# Altium schematic sheet lv_io_analog_input.SchDoc
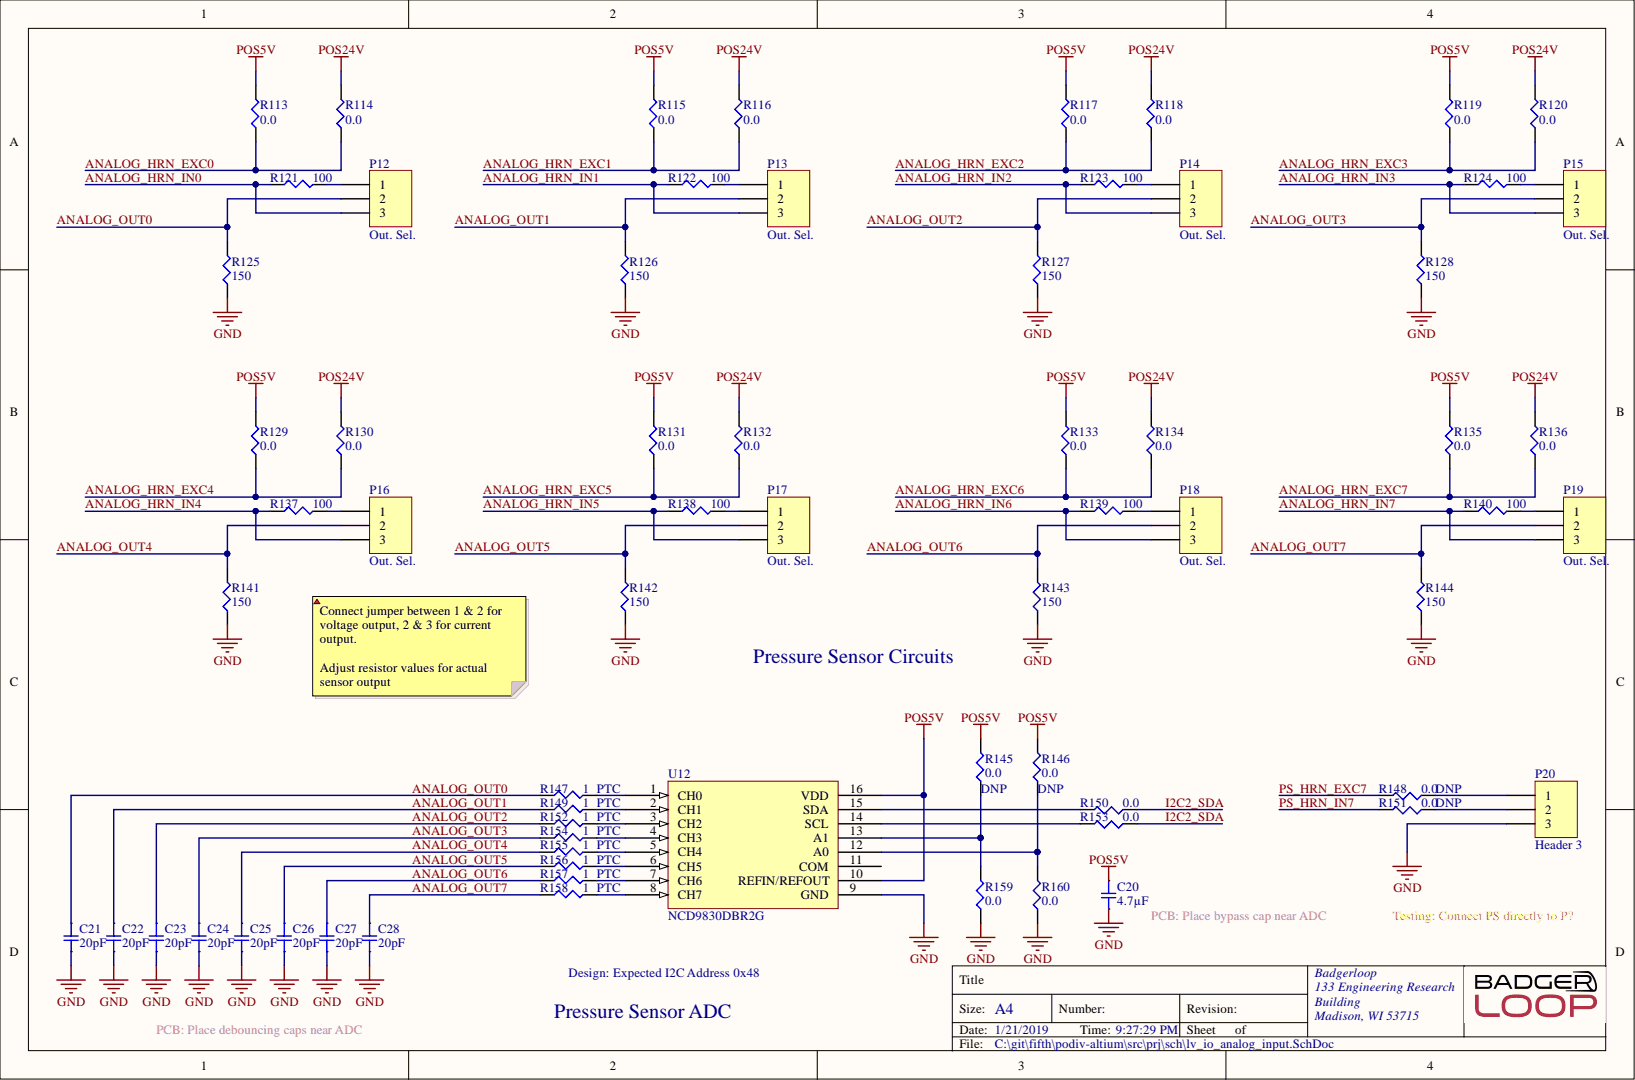
[diagram p0: lv_io_analog_input.SchDoc]

## Parts
C20  4.7µF  [SMD-0805C]
C21  20pF  [SMD-0402C]
C22  20pF  [SMD-0402C]
C23  20pF  [SMD-0402C]
C24  20pF  [SMD-0402C]
C25  20pF  [SMD-0402C]
C26  20pF  [SMD-0402C]
C27  20pF  [SMD-0402C]
C28  20pF  [SMD-0402C]
P12  Out. Sel.  [Pin Header 1x3 TH Pitch 2.54mm]
P13  Out. Sel.  [Pin Header 1x3 TH Pitch 2.54mm]
P14  Out. Sel.  [Pin Header 1x3 TH Pitch 2.54mm]
P15  Out. Sel.  [Pin Header 1x3 TH Pitch 2.54mm]
P16  Out. Sel.  [Pin Header 1x3 TH Pitch 2.54mm]
P17  Out. Sel.  [Pin Header 1x3 TH Pitch 2.54mm]
P18  Out. Sel.  [Pin Header 1x3 TH Pitch 2.54mm]
P19  Out. Sel.  [Pin Header 1x3 TH Pitch 2.54mm]
P20  Header 3  [Pin Header 1x3 TH Pitch 2.54mm]
R113  0.0  [SMD-0402-RES]
R114  0.0  [SMD-0402-RES]
R115  0.0  [SMD-0402-RES]
R116  0.0  [SMD-0402-RES]
R117  0.0  [SMD-0402-RES]
R118  0.0  [SMD-0402-RES]
R119  0.0  [SMD-0402-RES]
R120  0.0  [SMD-0402-RES]
R121  100  [SMD-0402-RES]
R122  100  [SMD-0402-RES]
R123  100  [SMD-0402-RES]
R124  100  [SMD-0402-RES]
R125  150  [SMD-0603-RES]
R126  150  [SMD-0603-RES]
R127  150  [SMD-0603-RES]
R128  150  [SMD-0603-RES]
R129  0.0  [SMD-0402-RES]
R130  0.0  [SMD-0402-RES]
R131  0.0  [SMD-0402-RES]
R132  0.0  [SMD-0402-RES]
R133  0.0  [SMD-0402-RES]
R134  0.0  [SMD-0402-RES]
R135  0.0  [SMD-0402-RES]
R136  0.0  [SMD-0402-RES]
R137  100  [SMD-0402-RES]
R138  100  [SMD-0402-RES]
R139  100  [SMD-0402-RES]
R140  100  [SMD-0402-RES]
R141  150  [SMD-0603-RES]
R142  150  [SMD-0603-RES]
R143  150  [SMD-0603-RES]
R144  150  [SMD-0603-RES]
R145  0.0  [SMD-0402-RES]
R146  0.0  [SMD-0402-RES]
R147  1  Features: PTC  [SMD-0603-RES]
R148  0.0  [SMD-0402-RES]
R149  1  Features: PTC  [SMD-0603-RES]
R150  0.0  [SMD-0402-RES]
R151  0.0  [SMD-0402-RES]
R152  1  Features: PTC  [SMD-0603-RES]
R153  0.0  [SMD-0402-RES]
R154  1  Features: PTC  [SMD-0603-RES]
R155  1  Features: PTC  [SMD-0603-RES]
R156  1  Features: PTC  [SMD-0603-RES]
R157  1  Features: PTC  [SMD-0603-RES]
R158  1  Features: PTC  [SMD-0603-RES]
R159  0.0  [SMD-0402-RES]
R160  0.0  [SMD-0402-RES]
U12  NCD9830DBR2G  [TSOP65P640X120_HS-17N]

## Nets
GND: C20.2 C21.2 C22.2 C23.2 C24.2 C25.2 C26.2 C27.2 C28.2 P20.3 R125.1 R126.1 R127.1 R128.1 R141.1 R142.1 R143.1 R144.1 R159.1 R160.1 U12.9(GND)
POS5V: C20.1 R113.2 R115.2 R117.2 R119.2 R129.2 R131.2 R133.2 R135.2 R145.2 R146.2 U12.10(REFIN/REFOUT) U12.16(VDD)
POS24V: R114.2 R116.2 R118.2 R120.2 R130.2 R132.2 R134.2 R136.2
ANALOG_HRN_EXC0: R113.1 R114.1
ANALOG_HRN_EXC1: R115.1 R116.1
ANALOG_HRN_EXC2: R117.1 R118.1
ANALOG_HRN_EXC3: R119.1 R120.1
ANALOG_HRN_EXC4: R129.1 R130.1
ANALOG_HRN_EXC5: R131.1 R132.1
ANALOG_HRN_EXC6: R133.1 R134.1
ANALOG_HRN_EXC7: R135.1 R136.1
ANALOG_HRN_IN0: P12.3 R121.1
ANALOG_HRN_IN1: P13.3 R122.1
ANALOG_HRN_IN2: P14.3 R123.1
ANALOG_HRN_IN3: P15.3 R124.1
ANALOG_HRN_IN4: P16.3 R137.1
ANALOG_HRN_IN5: P17.3 R138.1
ANALOG_HRN_IN6: P18.3 R139.1
ANALOG_HRN_IN7: P19.3 R140.1
ANALOG_OUT0: C21.1 P12.2 R125.2 R147.1
ANALOG_OUT1: C22.1 P13.2 R126.2 R149.1
ANALOG_OUT2: C23.1 P14.2 R127.2 R152.1
ANALOG_OUT3: C24.1 P15.2 R128.2 R154.1
ANALOG_OUT4: C25.1 P16.2 R141.2 R155.1
ANALOG_OUT5: C26.1 P17.2 R142.2 R156.1
ANALOG_OUT6: C27.1 P18.2 R143.2 R157.1
ANALOG_OUT7: C28.1 P19.2 R144.2 R158.1
I2C2_SDA: R150.1 R153.1
PS_HRN_EXC7: R148.2
PS_HRN_IN7: R151.2
NetP12_1: P12.1 R121.2
NetP13_1: P13.1 R122.2
NetP14_1: P14.1 R123.2
NetP15_1: P15.1 R124.2
NetP16_1: P16.1 R137.2
NetP17_1: P17.1 R138.2
NetP18_1: P18.1 R139.2
NetP19_1: P19.1 R140.2
NetP20_1: P20.1 R148.1
NetP20_2: P20.2 R151.1
NetR145_1: R145.1 R159.2 U12.13(A1)
NetR146_1: R146.1 R160.2 U12.12(A0)
NetR147_2: R147.2 U12.1(CH0)
NetR149_2: R149.2 U12.2(CH1)
NetR150_2: R150.2 U12.15(SDA)
NetR152_2: R152.2 U12.3(CH2)
NetR153_2: R153.2 U12.14(SCL)
NetR154_2: R154.2 U12.4(CH3)
NetR155_2: R155.2 U12.5(CH4)
NetR156_2: R156.2 U12.6(CH5)
NetR157_2: R157.2 U12.7(CH6)
NetR158_2: R158.2 U12.8(CH7)

Not connected: U12 11(COM)

## Library data of the parts
C20 = GRM21BR60J475KA11L: CAP CER 4.7UF 6.3V X5R 0805; Manufacturer: Murata Electronics North America; @ qty: 0; Minimum Quantity: 1; Tolerance: ±10%; Unit Price (USD): 0.28; Applications: General Purpose; Component Kind: Standard; Datasheets: http://www.murata.com/~/media/webrenewal/support/library/catalog/products/capacitor/mlcc/c02e.pdf; Digi-Key Part Number: 490-9749-1-ND; Factory Stock: 0; Features: -; Height - Seated (Max): -; Image: //media.digikey.com/Renders/Murata%20Renders/0805%20(2012%20Metric)%20.85mm.jpg; Lead Spacing: -; Lead Style: -; Library Name: capacitor.SchLib; Mounting Type: Surface Mount, MLCC; Operating Temperature: -55°C ~ 85°C; Package / Case: 0805 (2012 Metric); Packaging: Cut Tape (CT); Part Number: GRM21BR60J475KA11L; Part Status: Active; Quantity Available: 2821; Ratings: -; Series: GRM; Size / Dimension: 0.079" L x 0.049" W (2.00mm x 1.25mm); Temperature Coefficient: X5R; Thickness (Max): 0.055" (1.40mm); Value: 4.7µF; Voltage: 6.3V
C21-C28 = GJM1555C1H200JB01D: CAP CER 20PF 50V NP0 0402; Manufacturer: Murata Electronics North America; @ qty: 0; Minimum Quantity: 1; Temperature Coefficient: C0G, NP0; Tolerance: ±5%; Unit Price (USD): 0.1; Applications: RF, Microwave, High Frequency; Component Kind: Standard; Datasheets: http://www.murata.com/~/media/webrenewal/support/library/catalog/products/capacitor/mlcc/c02e.pdf; Digi-Key Part Number: 490-3120-1-ND; Factory Stock: 0; Features: High Q, Low Loss; Height - Seated (Max): -; Image: //media.digikey.com/Renders/Murata%20Renders/ZRB-SERIES-0402.jpg; Lead Spacing: -; Lead Style: -; Library Name: capacitor.SchLib; Mounting Type: Surface Mount, MLCC; Operating Temperature: -55°C ~ 125°C; Package / Case: 0402 (1005 Metric); Packaging: Cut Tape (CT); Part Number: GJM1555C1H200JB01D; Part Status: Active; Quantity Available: 13133; Ratings: -; Series: GJM; Size / Dimension: 0.039" L x 0.020" W (1.00mm x 0.50mm); Thickness (Max): 0.022" (0.55mm); Value: 20pF; Voltage: 50V
P12-P20 = Header 3: Header, 3-Pin; Component Kind: Standard; LatestRevisionDate: 17-Jul-2002; LatestRevisionNote: Re-released for DXP Platform.; Library Name: connector.SchLib; Signal Integrity: Connector; Publisher: Altium Limited
R113-R120 R129-R136 R145 R146 R148 R150 R151 R153 R159 R160 = ERJ-2GE0R00X: RES SMD 0.0OHM JUMPER 1/10W 0402; Manufacturer: Panasonic Electronic Components; @ qty: 1500; Factory Stock: 0; Minimum Quantity: 1; Power (Watts): 0.1W, 1/10W; Unit Price (USD): 0.00384; Component Kind: Standard; Composition: Thick Film; Datasheets: http://industrial.panasonic.com/www-cgi/jvcr13pz.cgi?E+PZ+3+AOA0001+ERJ2GE0R00X+7+WW; Digi-Key Part Number: P0.0JCT-ND; Failure Rate: -; Features: Automotive AEC-Q200; Height - Seated (Max): 0.016" (0.40mm); Image: //media.digikey.com/Photos/Panasonic%20Photos/ERJ-PA2-Series.jpg; Library Name: resistor.SchLib; Number of Terminations: 2; Operating Temperature: -55°C ~ 155°C; Package / Case: 0402 (1005 Metric); Packaging: Cut Tape (CT); Part Number: ERJ-2GE0R00X; Part Status: Active; Quantity Available: 3152483; Series: ERJ; Size / Dimension: 0.039" L x 0.020" W (1.00mm x 0.50mm); Supplier Device Package: 0402; Temperature Coefficient: -; Tolerance: Jumper; Value: 0.0
  R145 R146 R148 R151: DNP: DNP
R121-R124 R137-R140 = ERJ-2GEJ101X: RES SMD 100 OHM 5% 1/10W 0402; Manufacturer: Panasonic Electronic Components; @ qty: 1500; Factory Stock: 0; Minimum Quantity: 1; Power (Watts): 0.1W, 1/10W; Temperature Coefficient: ±200ppm/°C; Unit Price (USD): 0.00350; Component Kind: Standard; Composition: Thick Film; Datasheets: http://industrial.panasonic.com/www-cgi/jvcr13pz.cgi?E+PZ+3+AOA0001+ERJ2GEJ101X+7+WW; Digi-Key Part Number: P100JCT-ND; Failure Rate: -; Features: Automotive AEC-Q200; Height - Seated (Max): 0.016" (0.40mm); Image: //media.digikey.com/Photos/Panasonic%20Photos/ERJ-PA2-Series.jpg; Library Name: resistor.SchLib; Number of Terminations: 2; Operating Temperature: -55°C ~ 155°C; Package / Case: 0402 (1005 Metric); Packaging: Cut Tape (CT); Part Number: ERJ-2GEJ101X; Part Status: Active; Quantity Available: 3114113; Series: ERJ; Size / Dimension: 0.039" L x 0.020" W (1.00mm x 0.50mm); Supplier Device Package: 0402; Tolerance: ±5%; Value: 100
R125-R128 R141-R144 = ERJ-P03D1500V: RES SMD 150 OHM 0.5% 1/5W 0603; Manufacturer: Panasonic Electronic Components; @ qty: 1500; Factory Stock: 0; Minimum Quantity: 1; Power (Watts): 0.2W, 1/5W; Temperature Coefficient: ±150ppm/°C; Unit Price (USD): 0.06582; Component Kind: Standard; Composition: Thick Film; Datasheets: https://industrial.panasonic.com/cdbs/www-data/pdf/RDA0000/AOA0000C243.pdf; Digi-Key Part Number: P16013CT-ND; Failure Rate: -; Features: Automotive AEC-Q200, Pulse Withstanding; Height - Seated (Max): 0.022" (0.55mm); Image: //media.digikey.com/Photos/Panasonic%20Photos/MFG_ERJ-P6W%20Series.jpg; Library Name: resistor.SchLib; Number of Terminations: 2; Operating Temperature: -55°C ~ 155°C; Package / Case: 0603 (1608 Metric); Packaging: Cut Tape (CT); Part Number: ERJ-P03D1500V; Part Status: Active; Quantity Available: 4150; Series: ERJ; Size / Dimension: 0.063" L x 0.031" W (1.60mm x 0.80mm); Supplier Device Package: 0603; Tolerance: ±0.5%; Value: 150
R147 R149 R152 R154-R158 = PRG18BB470MB1RB: PTC RESET FUSE 6V 330MA 0603; Manufacturer: Murata; Manufacturer P/N: PRG18BC1R0MM1RB; @ qty: 1500; Factory Stock: 0; Power (Watts): 0.167W, 1/6W; Temperature Coefficient: ±250ppm/°C; Tolerance: ±1%; Unit Price (USD): 0.10080; Component Kind: Standard; Composition: Thick Film; Digi-Key Part Number: 490-8505-1-ND; Digikey Link: https://www.digikey.com/product-detail/en/murata-electronics-north-america/PRG18BC1R0MM1RB/490-8505-1-ND/4380768; Failure Rate: -; Height - Seated (Max): 0.016" (0.40mm); Image: //media.digikey.com/Photos/Panasonic%20Photos/ERJ-PA2-Series.jpg; Library Name: temp.SchLib; Minimum Quantity: 1; Number of Terminations: 2; Operating Temperature: -55°C ~ 125°C; Package / Case: 0603; Packaging: Cut Tape (CT); Part Status: Active; Quantity Available: 14922; Size / Dimension: 0.039" L x 0.020" W (1.00mm x 0.50mm); Supplier Device Package: 0402; Value: 1
U12 = NCD9830DBR2G: IC ADC 8BIT 8CH I2C 16TSSOP; Manufacturer: ON Semiconductor; Component Kind: Standard; Cost: 2.70; Digikey Link: https://www.digikey.com/product-detail/en/on-semiconductor/NCD9830DBR2G/NCD9830DBR2GOSCT-ND/9086945; Digikey P/N: NCD9830DBR2GOSCT-ND; Library Name: ic.SchLib; Manufacturer P/N: NCD9830DBR2G
